# Altium schematic sheet AIM2_v0.9_ESP.SchDoc
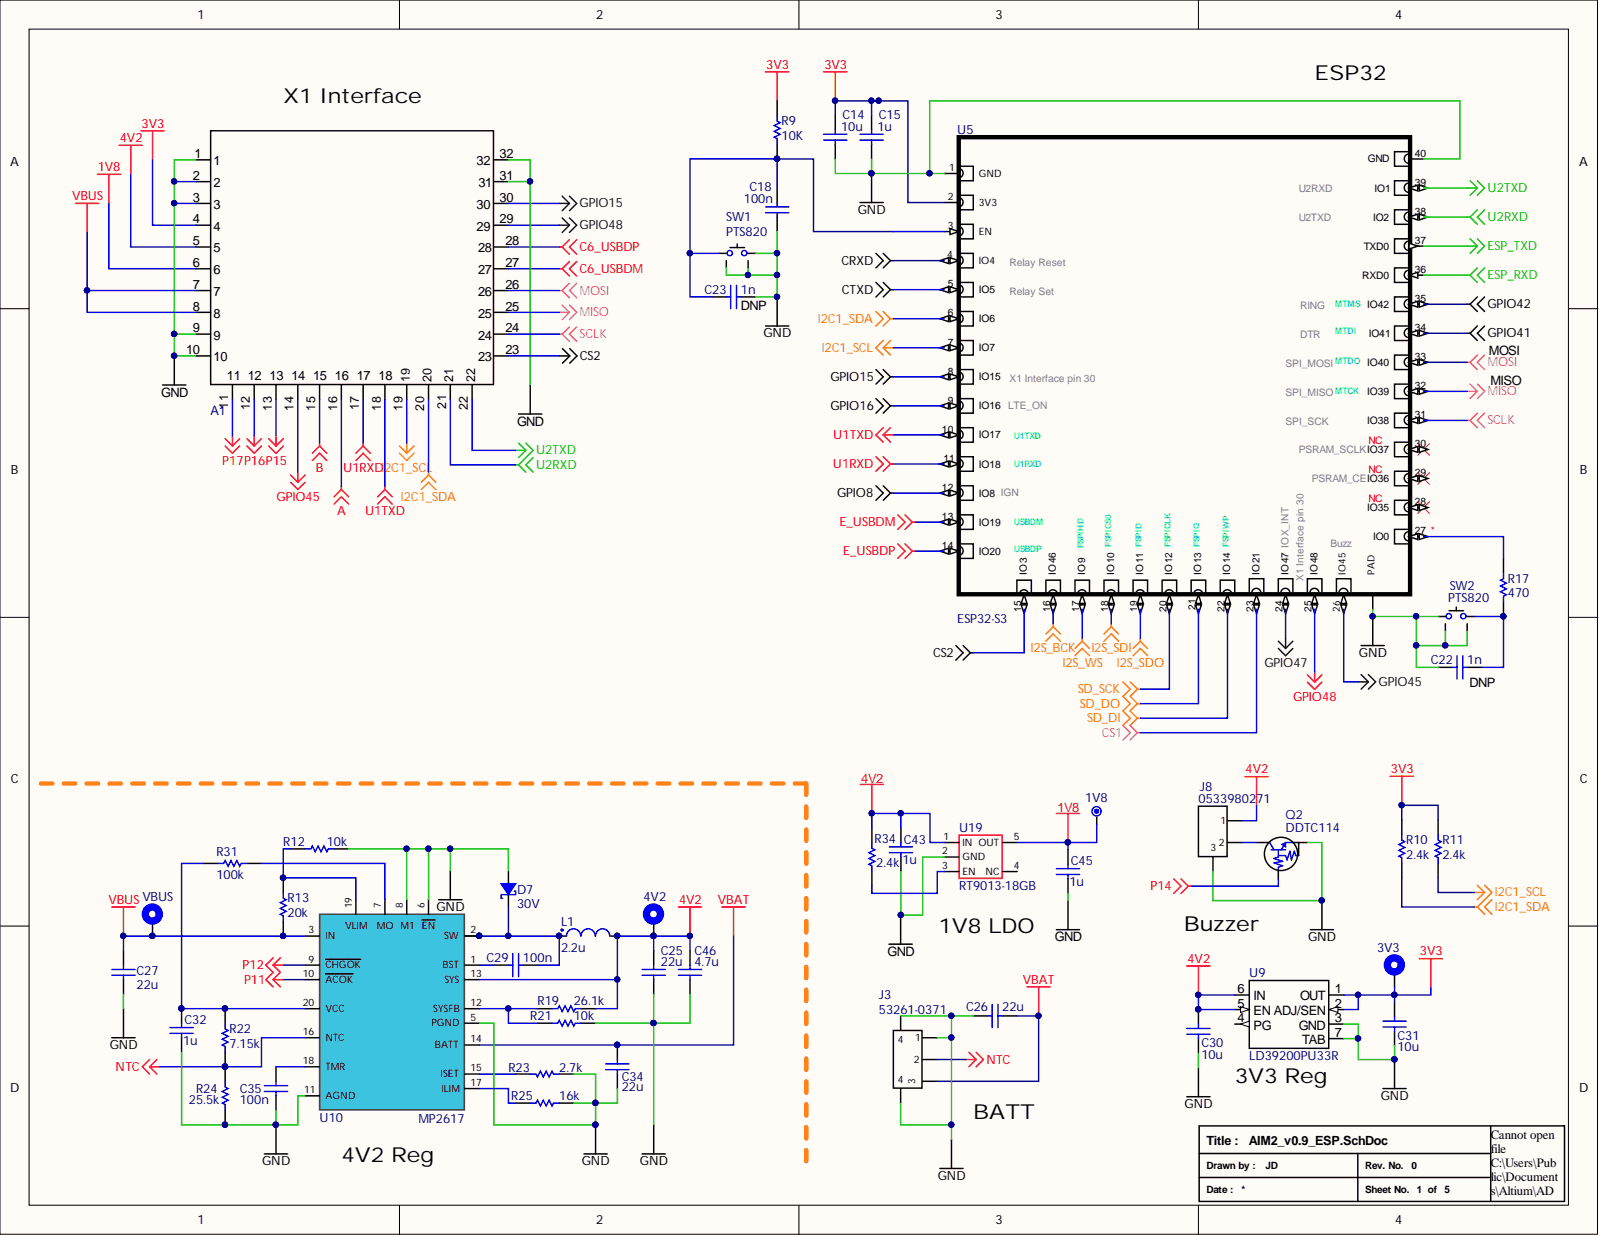
[diagram p0: AIM2_v0.9_ESP.SchDoc]

## Parts
1V8  Testpoint_1mm  [testpoint_1mm]  (value not drawn)
3V3  Testpoint_1_5  [Testpoint_1_5]  (value not drawn)
4V2  Testpoint_1_5  [Testpoint_1_5]  (value not drawn)
A1  X1 Interface  [Expansion_32x32]
C14  10u  [CAP_0603]
C15  1u  [CAP_0402]
C18  100n  [CAP_0402]
C22  1n  [CAP_0402_ghost]
C23  1n  [CAP_0402_ghost]
C25  22u  [CAP_1210a]
C26  22u  [CAP_0805]
C27  22u  [CAP_1210a]
C29  100n  [CAP_0402]
C30  10u  [CAP_0603]
C31  10u  [CAP_0603]
C32  1u  [CAP_0402]
C34  22u  [CAP_0805]
C35  100n  [CAP_0402]
C43  1u  [CAP_0402]
C45  1u  [CAP_0402]
C46  4.7u  [CAP_0805]
D7  30V  [SOD123F-2]
J3  53261-0371  [Molex_pblade_53261-0371]
J8  0533980271  [Molex_pblade_0533980271]
L1  2.2u  [Ind_5252]
Q2  DDTC114  [SOT323]
R9  10K  [RES_0402]
R10  2.4k  [RES_0402]
R11  2.4k  [RES_0402]
R12  10k  [RES_0603]
R13  20k  [RES_0603]
R17  470  [RES_0402]
R19  26.1k  [RES_0603]
R21  10k  [RES_0603]
R22  7.15k  [RES_0402]
R23  2.7k  [RES_0402]
R24  25.5k  [RES_0402]
R25  16k  [RES_0402]
R31  100k  [RES_0402]
R34  2.4k  [RES_0402]
SW1  PTS820  [SW_PTS820J25K]
SW2  PTS820  [SW_PTS820J25K]
U5  ESP32-S3  [ESP32_S3_WROOM]
U9  LD39200PU33R  [DFN-6_3x3]
U10  MP2617  [MP2617]
U19  RT9013-18GB  [SOT23-5]
VBUS  Testpoint_1_5  [Testpoint_1_5]  (value not drawn)

## Nets
1V8: 1V8.1 A1.6 C45.2 U19.5(OUT)
3V3: 3V3.1 A1.4 C14.2 C15.2 C31.2 R9.2 R10.2 R11.2 U5.2(3V3) U9.1(OUT) U9.2(ADJ/SEN)
4V2: 4V2.1 A1.5 C25.2 C30.2 C43.2 C46.2 J8.1 L1.2 R19.2 R34.2 U9.5(EN) U9.6(IN) U10.13(SYS) U19.1(IN)
A: A1.16
B: A1.15
C6_USBDM: A1.27
C6_USBDP: A1.28
CRXD: U5.4(IO4)
CS1: U5.23(IO21)
CS2: A1.23 U5.15(IO3)
CTXD: U5.5(IO5)
E_USBDM: U5.13(IO19)
E_USBDP: U5.14(IO20)
ESP_RXD: U5.36(RXD0)
ESP_TXD: U5.37(TXD0)
GND: A1.1 A1.2 A1.3 A1.9 A1.10 A1.31 A1.32 C14.1 C15.1 C18.1 C22.2 C23.2 C25.1 C26.1 C27.1 C30.1 C31.1 C32.1 C34.1 C35.1 C43.1 C45.1 C46.1 D7.A J3.1 J3.4 J8.3 Q2.2 R12.2 R21.2 R23.1 R24.1 R25.2 SW1.1 SW1.3 SW1.4 SW2.1 SW2.3 SW2.4 U5.0 U5.1(GND) U5.40(GND) U9.3(GND) U9.7(TAB) U10.5(PGND) U10.6(EN) U10.8(M1) U10.11(AGND) U19.2(GND)
GPIO8: U5.12(IO8)
GPIO15: A1.30 U5.8(IO15)
GPIO16: U5.9(IO16)
GPIO41: U5.34(IO41)
GPIO42: U5.35(IO42)
GPIO45: A1.14 U5.26
GPIO47: U5.24(IO47)
GPIO48: A1.29 U5.25(IO48)
I2C1_SCL: A1.19 R11.1 U5.7(IO7)
I2C1_SDA: A1.20 R10.1 U5.6(IO6)
I2S_BCK: U5.16(IO46)
I2S_SDI: U5.18(IO10)
I2S_SDO: U5.19(IO11)
I2S_WS: U5.17(IO9)
MISO: A1.25 U5.32(IO39)
MOSI: A1.26 U5.33(IO40)
NTC: J3.2 R22.1 R24.2 U10.16(NTC)
P11: U10.10(ACOK)
P12: U10.9(CHGOK)
P14: Q2.1
P15: A1.13
P16: A1.12
P17: A1.11
SCLK: A1.24 U5.31(IO38)
SD_DI: U5.22(IO14)
SD_DO: U5.21(IO13)
SD_SCK: U5.20(IO12)
U1RXD: A1.17 U5.11(IO18)
U1TXD: A1.18 U5.10(IO17)
U2RXD: A1.21 U5.38(IO2)
U2TXD: A1.22 U5.39(IO1)
VBAT: C26.2 C34.2 J3.3 U10.14(BATT)
VBUS: A1.7 A1.8 C27.2 R13.1 U10.3(IN) VBUS.1
NetC18_2: C18.2 C23.1 R9.1 SW1.2 U5.3(EN)
NetC22_1: C22.1 R17.1 SW2.2
NetC29_1: C29.1 U10.1(BST)
NetC29_2: C29.2 D7.K L1.1 U10.2(SW) U10.4
NetC32_2: C32.2 R22.2 R31.2 U10.20(VCC)
NetC35_2: C35.2 U10.18(TMR)
NetJ8_2: J8.2 Q2.3
NetR12_1: R12.1 R13.2 U10.19
NetR17_2: R17.2 U5.27(IO0)
NetR19_1: R19.1 R21.1 U10.12(SYSFB)
NetR23_2: R23.2 U10.15(ISET)
NetR25_1: R25.1 U10.17(ILIM)
NetR31_1: R31.1 U10.7(MO)
NetR34_1: R34.1 U19.3(EN)

Not connected: U5 28(IO35) 29(PSRAM_CE_IO36) 30(PSRAM_SCLK_IO37); U9 4(PG); U19 4(NC)

## Library data of the parts
A1 = Expansion_32x32: Component Kind: Standard; Library Name: SW.SchLib; Value: X1 Interface
C14 C30 C31 = Cap_10uF,10V, X5R, 10%,0603: Component Kind: Standard; Library Name: Cap.SchLib; Supplier 1: Mouser; Supplier Part Number 1: 81-GRT188R61A106KE3D; Supplier Part Number 4: 81-GRM188R61A106KALD; Value: 10u
C15 C32 C43 C45 = Cap_1uF,10V,20%,X5R,0402: Component Kind: Standard; Library Name: Cap.SchLib; Supplier 1: Mouser; Supplier Part Number 1: 81-GRM155R61A105ME1D; Value: 1u
C18 C29 C35 = Cap_100nF,50V,X5R,10%,0402: Component Kind: Standard; Library Name: Cap.SchLib; Supplier 1: Mouser; Supplier Part Number 1: 81-GRM155R61H104KE4D; Value: 100n
C22 C23 = Cap_1nF,16V,X5R,10%,0402: Component Kind: Standard; Library Name: Cap.SchLib; Supplier 1: Mouser; Supplier Part Number 1: 81-GRM155R61C102KA1D; Value: 1n
C25 C27 = Cap_22uF,25V,X7R,10%,1210: Component Kind: Standard; Library Name: Cap.SchLib; Supplier 1: Mouser; Supplier Part Number 1: 963-TMK325B7226KM-PR; Value: 22u
C26 C34 = Cap_22uF,25V,X5R,20%,0805: Component Kind: Standard; Library Name: Cap.SchLib; Supplier 1: Mouser; Value: 22u
  C26: Supplier Part Number 1: 81-GRM21BR61E226ME4L
  C34: Supplier Part Number 1: 81-GRM31CR61E226KE5L
C46 = Cap_4.7uF,50V,10%,X5R,0805: Component Kind: Standard; Library Name: Cap.SchLib; Supplier 1: Digi-Key; Supplier Part Number 1: 490-12394-1-ND; Supplier Part Number 2: 963-TMK212BJ475KG-T; Value: 4.7u
D7 = Schot_30V,1A,0.43Vf,30AIfsm,SOD-123FL-2: Component Kind: Standard; Library Name: Diode.SchLib; Supplier 1: Mouser; Supplier Part Number 1: 755-RB160MM-30TFTR; Value: 30V
J3 = Molex_pblade_53261-0371: Component Kind: Standard; Library Name: SW.SchLib; Supplier 1: Mouser; Supplier Part Number 1: 538-53261-0371; Value: 53261-0371
J8 = Molex_pblade_0533980271: Component Kind: Standard; Library Name: SW.SchLib; Supplier 1: Mouser; Supplier Part Number 1: 538-53398-0271; Value: 0533980271
L1 = Ind_2.2uH,5.1A,47.6mOhm,20%,5252: Component Kind: Standard; Value: 2.2u; Library Name: Mix_Interim.SchLib
Q2 = BJT_NPN_10kOhm,200mW: Component Kind: Standard; Library Name: Trans.SchLib; Supplier 1: Mouser; Supplier Part Number 1: 621-DDTC114YUA-F; Value: DDTC114
R9 = Res_10K, 1%,1/16W,0402: Component Kind: Standard; Library Name: RES.SchLib; Supplier 1: Mouser; Supplier Part Number 1: 603-RC0402FR-0710KL; Value: 10K
R10 R11 R34 = Res_2.4k,1%,62.5mW,0402: Component Kind: Standard; Library Name: RES.SchLib; Supplier 1: Mouser; Supplier Part Number 1: 603-RC0402FR-072K4L; Value: 2.4k
R12 R21 = Res_10k,1%,100mW,0603: Component Kind: Standard; Library Name: RES.SchLib; Supplier 1: Mouser; Supplier Part Number 1: 603-RC0603FR-0710KL; Value: 10k
R13 = Res_20k,1%,1/16W,0603: Component Kind: Standard; Library Name: RES.SchLib; Supplier 1: Mouser; Supplier Part Number 1: 603-RC0603FR-0720KL; Value: 20k
R17 = Res_470R,1%,100mW,0402: Component Kind: Standard; Library Name: RES.SchLib; Supplier 1: Mouser; Supplier Part Number 1: 667-ERJ-2RKF4700X; Value: 470
R19 = Res_26.1k,1%,1/16W,0603: Component Kind: Standard; Library Name: RES.SchLib; Supplier 1: Mouser; Supplier Part Number 1: 603-RC0603FR-0726K1L; Value: 26.1k
R22 = Res_7.15k,1%,62.5mW,0402: Component Kind: Standard; Library Name: RES.SchLib; Supplier 1: Mouser; Supplier Part Number 1: 603-RC0402FR-137K15L; Value: 7.15k
R23 = Res_2.7k,1%,1/16W,0402: Component Kind: Standard; Library Name: RES.SchLib; Supplier 1: Mouser; Supplier Part Number 1: 603-RC0402FR-072K7L; Value: 2.7k
R24 = Res_25.5k,1/%,1/16W,0402: Component Kind: Standard; Library Name: RES.SchLib; Supplier 1: Mouser; Supplier Part Number 1: 71-CRCW0402-25.5K-E3; Value: 25.5k
R25 = Res_16k,1%,62.5mW,0402: Component Kind: Standard; Library Name: RES.SchLib; Supplier 1: Mouser; Supplier Part Number 1: 603-RC0402FR-0716KL; Value: 16k
R31 = Res_100k,5%,1/16W, 0402: Component Kind: Standard; Library Name: RES.SchLib; Supplier 1: Mouser; Supplier Part Number 1: 603-RC0402JR-07100KL; Value: 100k
SW1 SW2 = TSw_PTS820J25K: Component Kind: Standard; Library Name: SW.SchLib; Supplier 1: Mouser; Supplier Part Number 1: 611-PTS820J25KSMTR; Value: PTS820
U5 = ESP32-S3-WROOM-I: Component Kind: Standard; Library Name: ICs.SchLib; Supplier 1: Mouser; Supplier Part Number 1: 356-EP32S3DVKTC1N8R8; Value: ESP32-S3
U9 = LDO_3.3V,2A,40dB,DFN-6_3x3: Component Kind: Standard; Library Name: ICs.SchLib; Supplier 1: Mouser; Supplier Part Number 1: 511-LD39200PU33R; Value: LD39200PU33R
U19 = LDO_1.8V,500mA,SOT23-5: Component Kind: Standard; Library Name: ICs.SchLib; Supplier 1: Digi-Key; Supplier Part Number 1: 1028-RT9013-18GBCT-ND; Value: RT9013-18GB
1V8 = Testpoint_1mm: Component Kind: Standard; Library Name: SW.SchLib
3V3 4V2 VBUS = Testpoint_1_5: Component Kind: Standard; Library Name: SW.SchLib
U10 = MP2617: Component Kind: Standard; Library Name: ICs.SchLib; Supplier 1: Mouser; Supplier Part Number 1: 946-MP2617GLP; Value: MP2617
